# Altium schematic sheet GammaMRICAB_2 - Power Management2.SchDoc
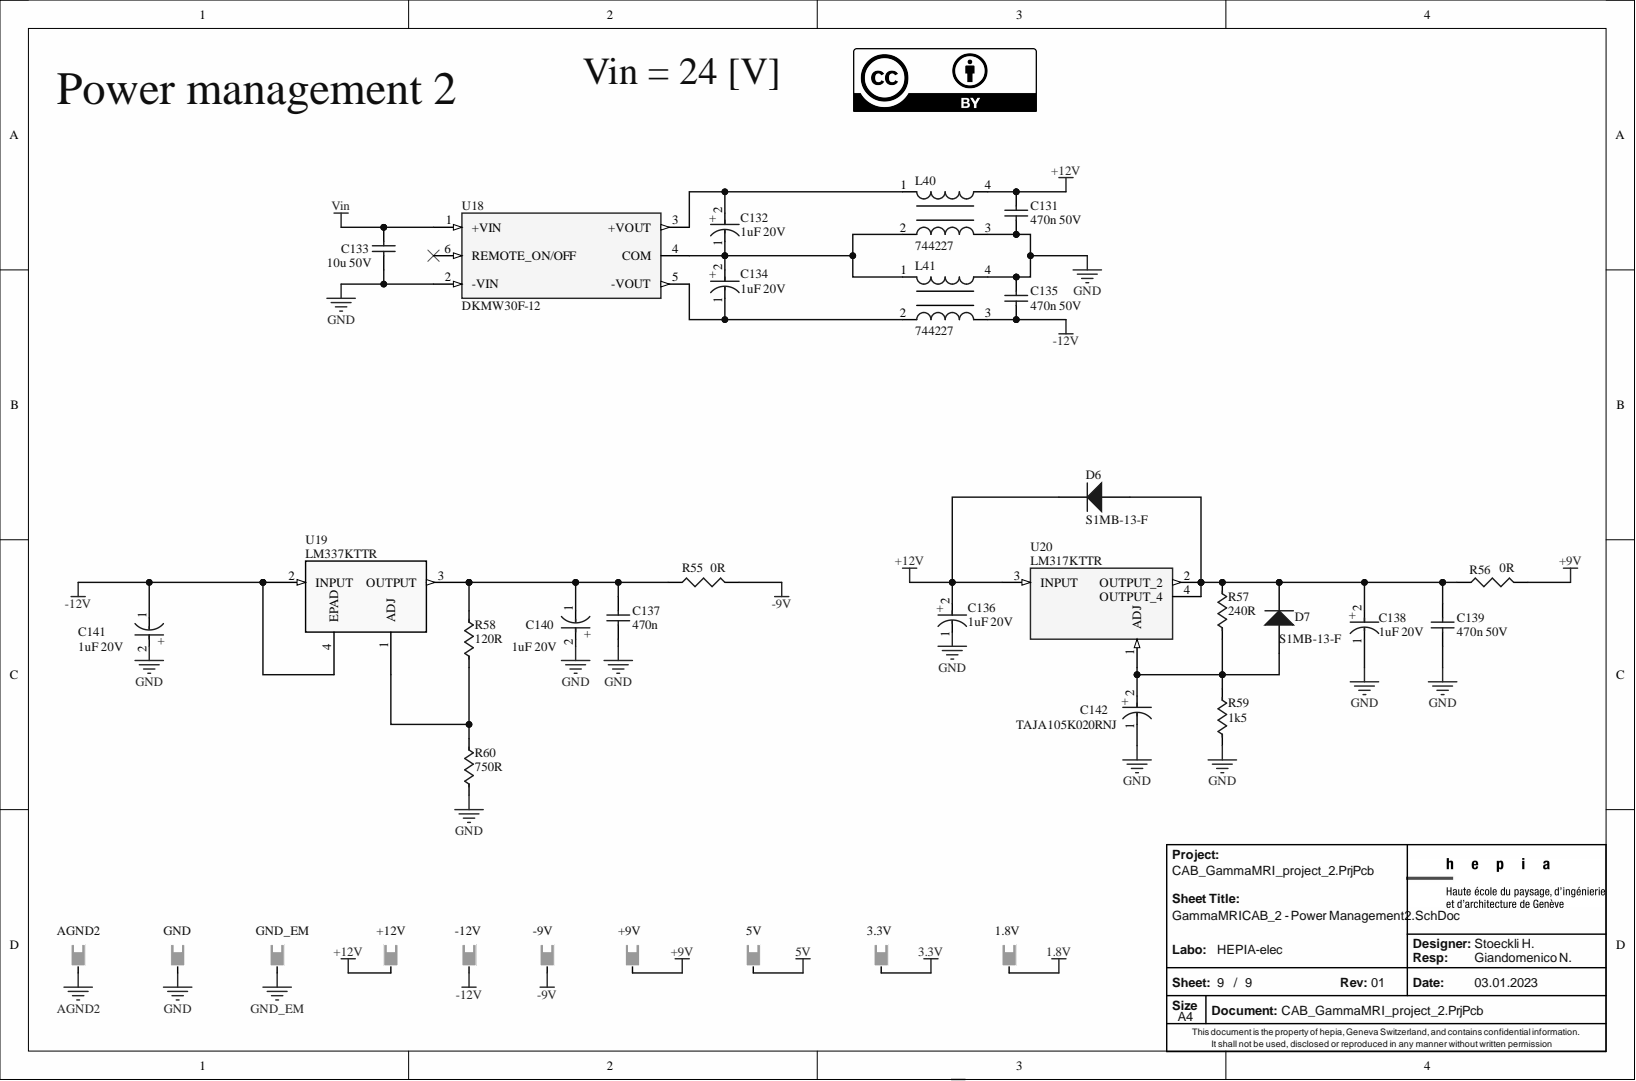
[diagram p0: GammaMRICAB_2 - Power Management2.SchDoc]

## Parts
1.8V  TP_COSSE_Hole  [COSSE_PAD]  (value not drawn)
3.3V  TP_COSSE_Hole  [COSSE_PAD]  (value not drawn)
5V  TP_COSSE_Hole  [COSSE_PAD]  (value not drawn)
+9V  TP_COSSE_Hole  [COSSE_PAD]  (value not drawn)
+12V  TP_COSSE_Hole  [COSSE_PAD]  (value not drawn)
-9V  TP_COSSE_Hole  [COSSE_PAD]  (value not drawn)
-12V  TP_COSSE_Hole  [COSSE_PAD]  (value not drawn)
AGND2  TP_COSSE_Hole  [COSSE_PAD]  (value not drawn)
C131  470n 50V  [1608[0603]]
C132  1uF 20V  [FP-TAJA-MFG]
C133  10u 50V  [INDC3216]
C134  1uF 20V  [FP-TAJA-MFG]
C135  470n 50V  [1608[0603]]
C136  1uF 20V  [FP-TAJA-MFG]
C137  470n  [1608[0603]]
C138  1uF 20V  [FP-TAJA-MFG]
C139  470n 50V  [1608[0603]]
C140  1uF 20V  [FP-TAJA-MFG]
C141  1uF 20V  [FP-TAJA-MFG]
C142  TAJA105K020RNJ  [FP-TAJA-MFG]
D6  S1MB-13-F  [DIOD-SMB-2_V]
D7  S1MB-13-F  [DIOD-SMB-2_V]
GND  TP_COSSE_Hole  [COSSE_PAD]  (value not drawn)
GND_EM  TP_COSSE_Hole  [COSSE_PAD]  (value not drawn)
L40  744227  [WE-SL2]
L41  744227  [WE-SL2]
R55  0R  [C1210_L]
R56  0R  [C1210_L]
R57  240R  [1608[0603]]
R58  120R  [1608[0603]]
R59  1k5  [1608[0603]]
R60  750R  [1608[0603]]
U18  DKMW30F-12  [SKMW30F12]
U19  LM337KTTR  [KTT3_6P22X6P6-M]
U20  LM317KTTR  [TO254P1524X483-4N]

## Nets
1.8V: 1.8V.1
3.3V: 3.3V.1
5V: 5V.1
+9V: +9V.1 R56.2
+12V: +12V.1 C131.2 C136.2 D6.2 L40.4 U20.3(INPUT)
-9V: -9V.1 R55.2
-12V: -12V.1 C135.1 C141.1 L41.3 U19.2(INPUT) U19.4(EPAD)
AGND2: AGND2.1
GND: C131.1 C133.1 C135.2 C136.1 C137.1 C138.1 C139.1 C140.2 C141.2 C142.1 GND.1 L40.3 L41.4 R59.2 R60.2 U18.2(-VIN)
GND_EM: GND_EM.1
Vin: C133.2 U18.1(+VIN)
NetC132_1: C132.1 C134.2 L40.2 L41.1 U18.4(COM)
NetC132_2: C132.2 L40.1 U18.3(+VOUT)
NetC134_1: C134.1 L41.2 U18.5(-VOUT)
NetC137_2: C137.2 C140.1 R55.1 R58.1 U19.3(OUTPUT)
NetC138_2: C138.2 C139.2 D6.1 D7.2 R56.1 R57.1 U20.2(OUTPUT_2) U20.4(OUTPUT_4)
NetC142_2: C142.2 D7.1 R57.2 R59.1 U20.1(ADJ)
NetR58_2: R58.2 R60.1 U19.1(ADJ)

Not connected: U18 6(REMOTE_ON/OFF)

## Library data of the parts
C131 C135 C137 C139 = Cap: Capacitor 470nF C0603 X6S 50V; Component Kind: Standard; Library Name: Miscellaneous Devices.IntLib; Signal Integrity: Cap; Simulation: CAP; Supplier1: Digikey
C132 C134 C136 C138 C140 C141 = CMP-2000-07216-2: TAJA105K020RNJ 1uF 20V  ±10% 9Ω 1206  SMD Tantalum Capacitor; Component Kind: Standard; Library Name: Altium Content Vault; Supplier1: Digikey; Supplier1PN1: 478-1650-1-ND
C133 = Cap: Capacitor 10uF C1206 X6S 50V; Component Kind: Standard; Library Name: Miscellaneous Devices.IntLib; Signal Integrity: Cap; Simulation: CAP; Supplier1: Digikey; Supplier1PN1: 1276-6736-1-ND
C142 = CMP-2000-07216-2: 1uF 20V  ±10% 9Ω 1206  SMD Tantalum Capacitor; Component Kind: Standard; Library Name: Altium Content Vault
D6 D7 = CMP-2000-07013-1: Surface Mount Glass Passivated Rectifier  , 1 A, 1.1 V, -65 to 150 degC, 2-Pin SMD, RoHS, Tape and Reel; Component Kind: Standard; Library Name: Altium Content Vault; Supplier1: Digikey; Supplier1PN1: S1MB-FDICT-ND
L40 L41 = CMP-0222-00049-1: SMD Common Mode Line Filter WE-SL2, L = 51.0 µH; Component Kind: Standard; Library Name: Altium Content Vault; Supplier1: Digikey; Supplier1PN1: 732-1481-1-ND
R55 R56 = Res3: Resistor 0Ohm 1206; Component Kind: Standard; Library Name: Miscellaneous Devices.IntLib; Signal Integrity: Res; Simulation: RESISTOR; Supplier1: Digikey; Supplier1PN1: RMCF1206ZT0R00CT-ND
R57 = D_RES-120: Thick Film Resistor, Boite Labo 0603, 240R 1%; Database Table Name: D_Resistors$; Component Kind: Standard; Supplier1: Digikey; Library Name: Database_Altium_Hepia_Elec.DbLib
R58 = D_RES-120: Thick Film Resistor, Boite Labo 0603, 120R 1%; Database Table Name: D_Resistors$; Component Kind: Standard; Supplier1: Digikey; Library Name: Database_Altium_Hepia_Elec.DbLib
R59 = D_RES-120: Thick Film Resistor, Boite Labo 0603, 1k5 1%; Database Table Name: D_Resistors$; Component Kind: Standard; Supplier1: Digikey; Library Name: Database_Altium_Hepia_Elec.DbLib
R60 = D_RES-120: Thick Film Resistor, Boite Labo 0603, 750R 1%; Database Table Name: D_Resistors$; Component Kind: Standard; Supplier1: Digikey; Library Name: Database_Altium_Hepia_Elec.DbLib
U18 = DKMW30F-12: DC-DC Regulated converter +12V -12V; Component Kind: Standard; Supplier1: Digikey; Supplier1PN1: 1866-1361-ND; Library Name: CAB_SCH_LIB.SchLib
U19 = LM337KTTR: No Description Available; Component Kind: Standard; Supplier1: Digikey; Supplier1PN1: 296-50354-1-ND; Library Name: CAB_SCH_LIB.SchLib
U20 = LM317KTTR: 1.5-A, 40-V, adjustable linear voltage regulator; Component Kind: Standard; Supplier1: Digikey; Supplier1PN1: 296-21390-1-ND; Library Name: CAB_SCH_LIB.SchLib
1.8V 3.3V 5V +9V +12V -9V -12V AGND2 GND GND_EM = TP_COSSE_Hole: Component Kind: Standard; Library Name: CAB_SCH_LIB.SchLib
